# Altium schematic sheet IMU3.3X.SchDoc(IMU3.3X)
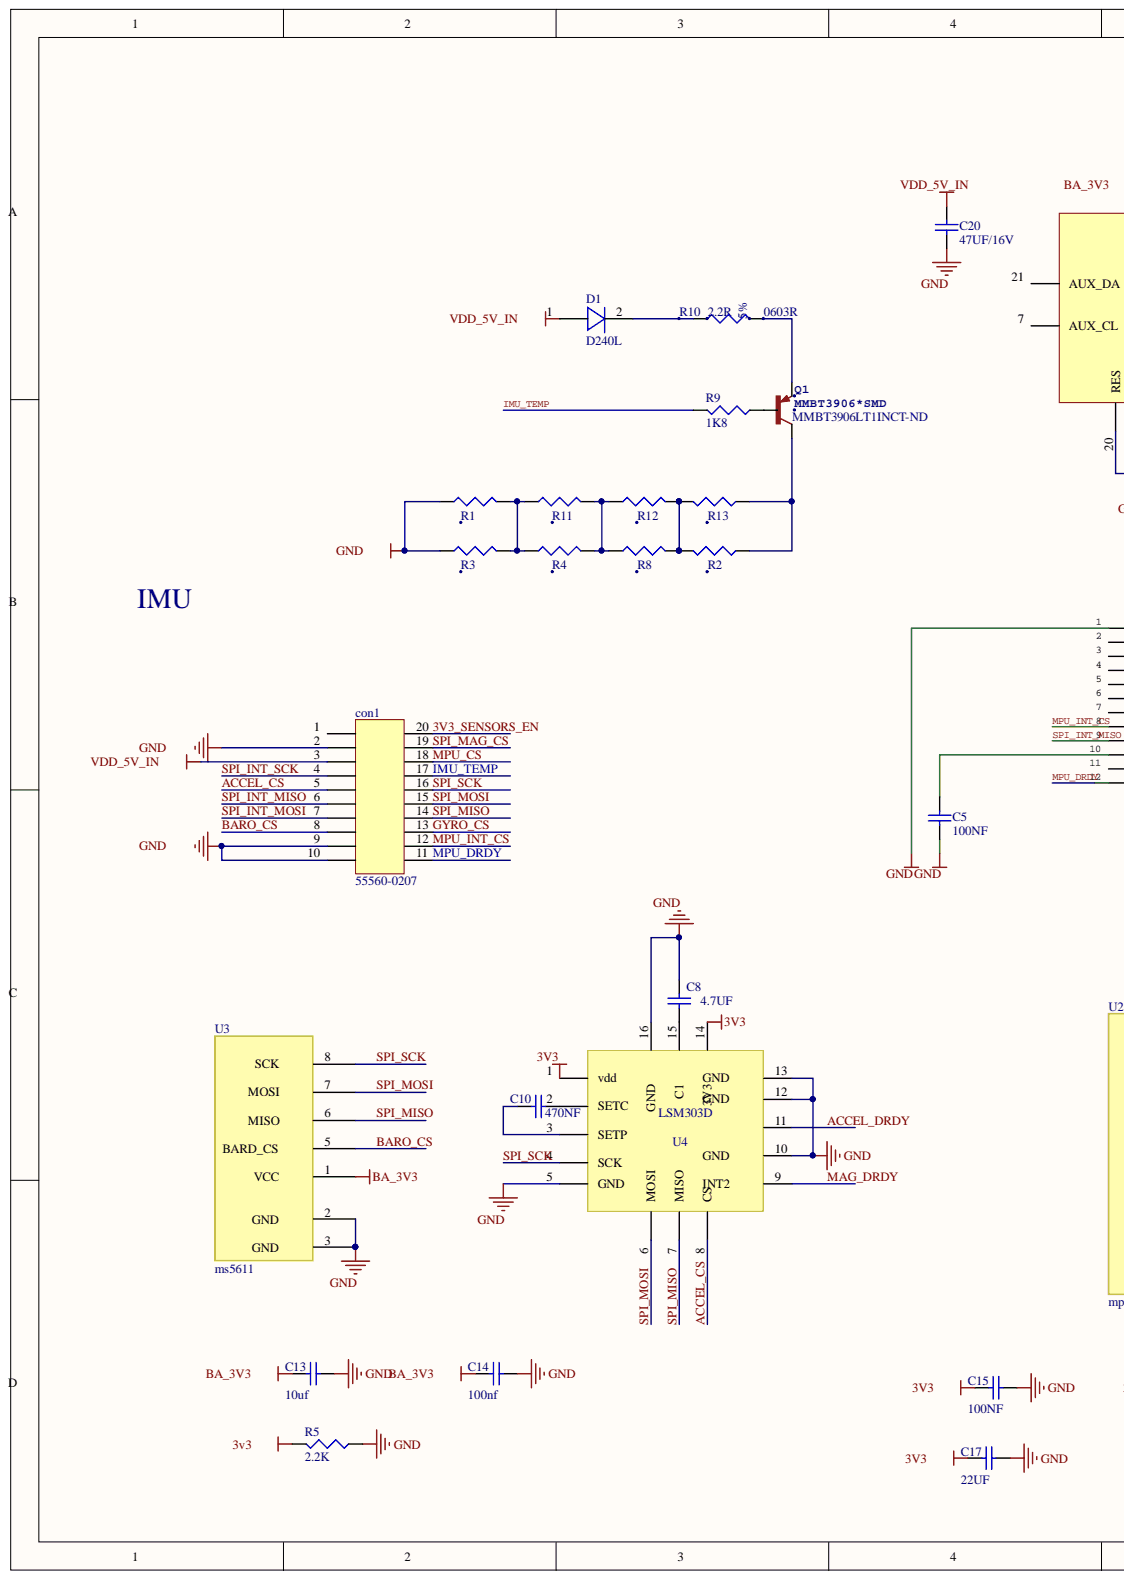
[diagram p0: IMU3.3X.SchDoc, left]
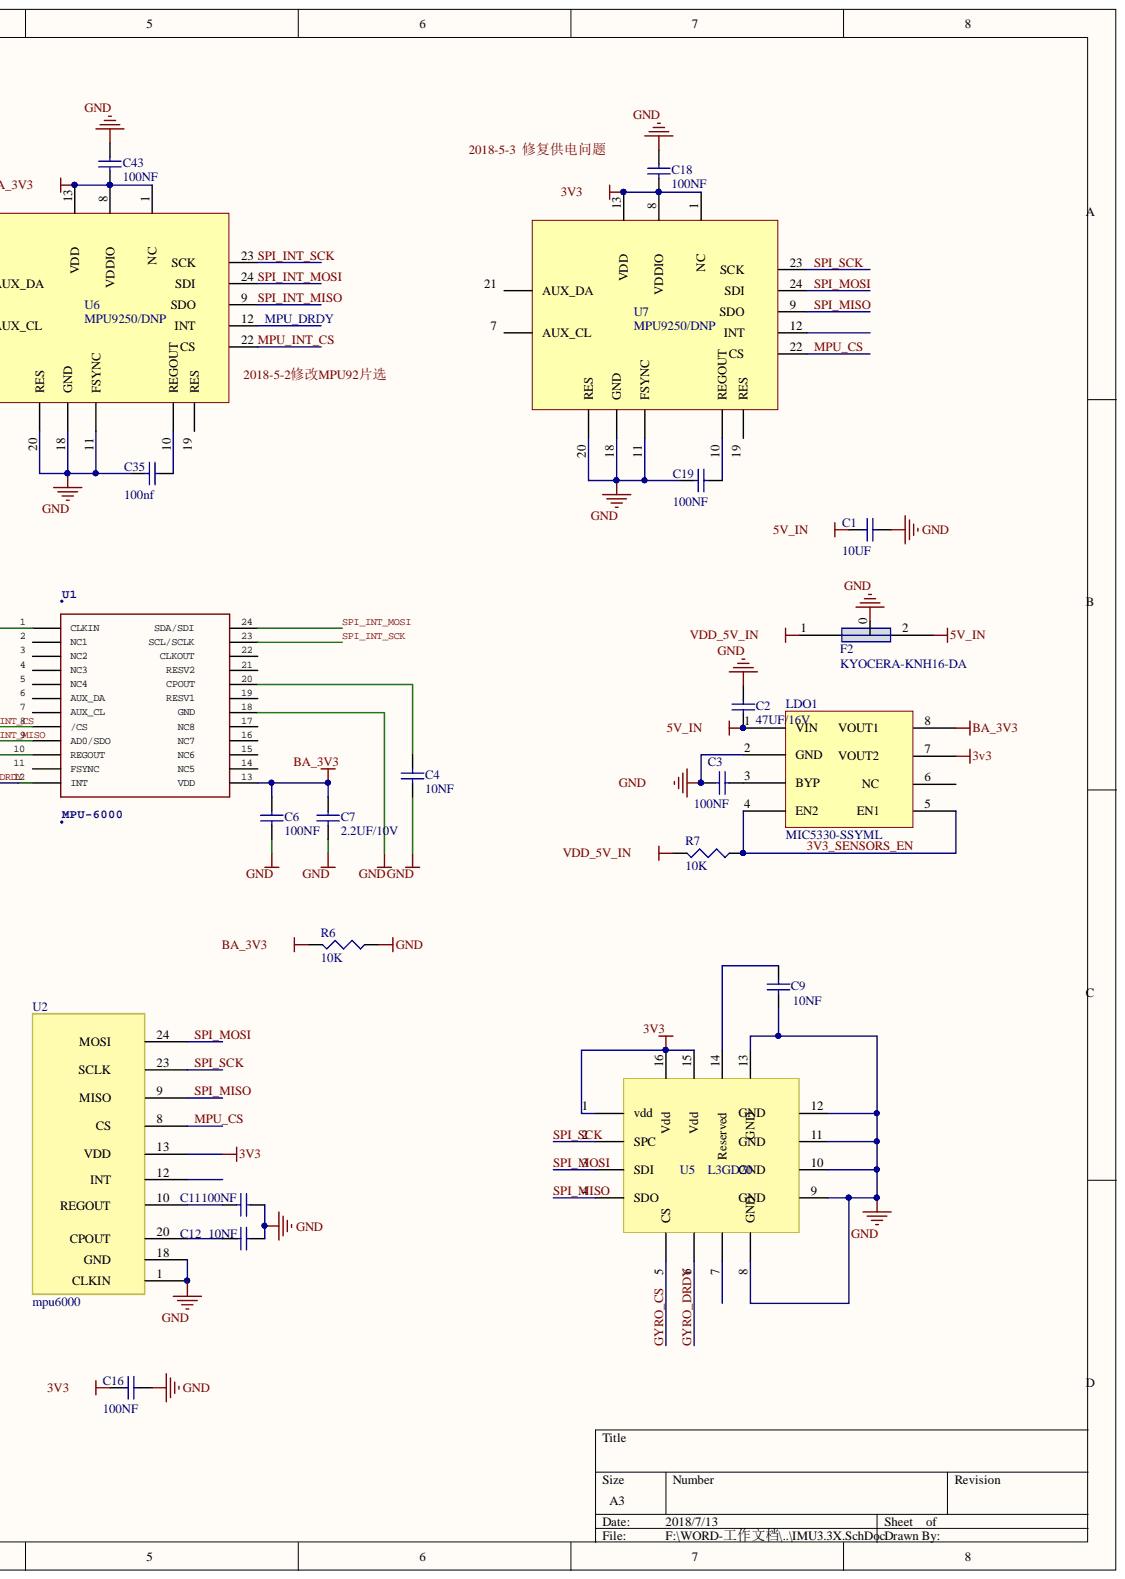
[diagram p1: IMU3.3X.SchDoc, right]

## Parts
C1  10UF  [0402CAP]
C2  47UF/16V  [0805CAP]
C3  100NF  [0402CAP]
C4  10NF  [0402CAP]
C5  100NF  [0402CAP]
C6  100NF  [0402CAP]
C7  2.2UF/10V  [0402CAP]
C8  4.7UF  [0805CAP]
C9  10NF  [0402CAP]
C10  470NF  [0402CAP]
C11  100NF  [0402CAP]
C12  10NF  [0402CAP]
C13  10uf  [0603c]
C14  100nf  [0402cap]
C15  100NF  [0402CAP]
C16  100NF  [0402CAP]
C17  22UF  [0805CAP]
C18  100NF  [0402CAP]
C19  100NF  [0402CAP]
C20  47UF/16V  [0805CAP]
C35  100nf  [0402CAP]
C43  100NF  [0402CAP]
con1  55560-0207  [55560-0207]
D1  D240L  [SOD-123]
F2  KYOCERA-KNH16-DA  [KYOCERA-KNH16-DA]
LDO1  MIC5330-SSYML  [MIC5530]
Q1  MMBT3906*SMD  Supplier Part Number 1: MMBT3906LT1INCT-ND  [SOT23-BEC]
R1  0R  [0603R]  (value not drawn)
R2  5R5/DNP  [0603R]  (value not drawn)
R3  5R5/DNP  [0603R]  (value not drawn)
R4  5R5/DNP  [0603R]  (value not drawn)
R5  2.2K  [0402r]
R6  10K  [0402r]
R7  10K  [0402r]
R8  5R5/DNP  [0603R]  (value not drawn)
R9  1K8  [0402r]
R10  2.2R  Tolerance: 5%  [0603R]
R11  0R  [0603R]  (value not drawn)
R12  51R  [0603R]  (value not drawn)
R13  51R  [0603R]  (value not drawn)
U1  MPU-6000  [MPU6000]
U2  mpu6000  [MPU6000]
U3  ms5611  [ms5611]
U4  LSM303D  [LSM303D]
U5  L3GD20  [L3GD20]
U6  MPU9250/DNP  [QNF24_0.4]
U7  MPU9250/DNP  [QNF24_0.4]

## Nets
3V3: C15.1 C16.1 C17.1 C18.1 LDO1.7 R5.2 U2.13 U4.1(vdd) U4.14 U5.1(vdd) U5.15 U5.16 U7.1 U7.8 U7.13
5V_IN: C1.1 C2.1 F2.2 LDO1.1(VIN)
BA_3V3: C6.2 C7.2 C13.1 C14.1 C43.1 LDO1.8 R6.2 U1.13 U3.1 U6.1 U6.8 U6.13
GND: C1.2 C2.2 C3.2 C4.1 C5.1 C6.1 C7.1 C8.2 C9.1 C11.2 C12.2 C13.2 C14.2 C15.2 C16.2 C17.2 C18.2 C19.1 C20.1 C35.1 C43.2 con1.2 con1.9 con1.10 F2.0 LDO1.2(GND) R1.1 R3.1 R5.1 R6.1 U1.1(CLKIN) U1.18 U2.1 U2.18 U3.2 U3.3 U4.5(GND) U4.10 U4.12 U4.13 U4.16 U5.8(GND) U5.9 U5.10 U5.11 U5.12 U5.13 U6.11(FSYNC) U6.18(GND) U6.20(RES) U7.11(FSYNC) U7.18(GND) U7.20(RES)
VDD_5V_IN: C20.2 con1.3 D1.1 F2.1 R7.2
3V3_SENSORS_EN: con1.20 LDO1.4(EN2) LDO1.5 R7.1
ACCEL_CS: con1.5 U4.8(CS)
ACCEL_DRDY: U4.11
BARO_CS: con1.8 U3.5
GYRO_CS: con1.13 U5.5(CS)
GYRO_DRDY: U5.6
IMU_TEMP: con1.17 R9.2
MAG_DRDY: U4.9
MPU_CS: con1.18 U2.8 U7.22
MPU_DRDY: con1.11 U1.12(INT) U6.12
MPU_INT_CS: con1.12 U1.8(/CS) U6.22
SPI_INT_MISO: con1.6 U1.9(AD0/SDO) U6.9
SPI_INT_MOSI: con1.7 U1.24 U6.24
SPI_INT_SCK: con1.4 U1.23 U6.23
SPI_MAG_CS: con1.19
SPI_MISO: con1.14 U2.9 U3.6 U4.7(MISO) U5.4(SDO) U7.9
SPI_MOSI: con1.15 U2.24 U3.7 U4.6(MOSI) U5.3(SDI) U7.24
SPI_SCK: con1.16 U2.23 U3.8 U4.4(SCK) U5.2(SPC) U7.23
NetC3_1: C3.1 LDO1.3(BYP)
NetC4_2: C4.2 U1.20
NetC5_2: C5.2 U1.10(REGOUT)
NetC8_1: C8.1 U4.15
NetC9_2: C9.2 U5.14
NetC10_1: C10.1 U4.3(SETP)
NetC10_2: C10.2 U4.2(SETC)
NetC11_1: C11.1 U2.10
NetC12_1: C12.1 U2.20
NetC19_2: C19.2 U7.10(REGOUT)
NetC35_2: C35.2 U6.10(REGOUT)
NetD1_2: D1.2 R10.1
NetQ1_B: Q1.B R9.1
NetQ1_C: Q1.C R2.1 R13.1
NetQ1_E: Q1.E R10.2
NetR1_2: R1.2 R3.2 R4.1 R11.1
NetR2_2: R2.2 R8.2 R12.2 R13.2
NetR4_2: R4.2 R8.1 R11.2 R12.1
2018-5-2修改MPU92片选:
2018-5-3  修复供电问题:

Not connected: con1 1; LDO1 6; U1 2(NC1) 3(NC2) 4(NC3) 5(NC4) 6(AUX_DA) 7(AUX_CL) 11(FSYNC) 14 15 16 17 19 21 22; U2 12; U5 7; U6 7(AUX_CL) 19(RES) 21(AUX_DA); U7 7(AUX_CL) 12 19(RES) 21(AUX_DA)

## Library data of the parts
C1 C2 C4-C20 C35 C43 = Cap: Capacitor; Value: 100pF; Component Kind: Standard; LatestRevisionDate: 17-Jul-2002; LatestRevisionNote: Re-released for DXP Platform.; Library Name: Miscellaneous Devices.IntLib; PackageDescription: Radial Cap, Thru-Hole; 2 Leads; 0.3 in Pin Spacing; PackageReference: RAD-0.3; Published: 8-Jun-2000; Signal Integrity: Cap; Simulation: CAP; Publisher: Altium Limited
C3 = Cap: Value: 100pF; Component Kind: Standard; LatestRevisionDate: 17-Jul-2002; LatestRevisionNote: Re-released for DXP Platform.; Library Name: Miscellaneous Devices.IntLib; PackageDescription: Radial Cap, Thru-Hole; 2 Leads; 0.3 in Pin Spacing; PackageReference: RAD-0.3; Published: 8-Jun-2000; Signal Integrity: Cap; Simulation: CAP; Publisher: Altium Limited
D1 = D204L: Component Kind: Standard; Library Name: CUAV.SchLib
LDO1 = MIC5530: Component Kind: Standard; Library Name: hack.SchLib
Q1 = MMBT3906*SMD: TRANSISTOR SWITCHING PNP SOT-23; PARTNO: MMBT 3906 LT1; Component Kind: Standard; Library Name: transistor-pnp.IntLib
R1 R3 R8 R10 R12 = Resistor: RES SMD 5.1 OHM 5% 1/4W 0603; Component Kind: Standard; Library Name: 3DR.SchLib
  R10: : 0603R; Value: 2.2R
R2 R4 R11 R13 = Resistor: Component Kind: Standard; Library Name: 3DR.SchLib
R5 = RMCF0402FT4K99: RES TF 4.99K OHM 1% 1/16W 0402; Manufacturer: Stackpole Electronics Inc; .Checked PCB: used; Power (Watts): 0.063W, 1/16W; Temperature Coefficient: ?00ppm/癈; Tolerance: ?%; Value: 4k99; .Checked SCH: used; Category: Resistors; Component Kind: Standard; ComponentLink1Description: http://www.seielect.com/Catalog/SEI-RMCF.pdf; ComponentLink1URL: http://www.seielect.com/Catalog/SEI-RMCF.pdf; Composition: Thick Film; Features: -; Height: 0.016" (0.40mm); Manufacturer Part Number: RMCF0402FT4K99; Number of Terminations: 2; Package / Case: 0402 (1005 Metric); Packaging: Cut Tape (CT); Price 1k [$]: 0.00384; Pricing 1: 1=0.04, 10=0.025, 50=0.0138, 100=0.0105, 250=0.0078, 500=0.00576, 1000=0.00384 (USD); Resistance (Ohms): 4.99K; RoHS: RoHS Compliant; Size / Dimension: 0.039" L x 0.020" W (1.00mm x 0.50mm); Stock 1: 48509; Supplier: Digikey; Supplier 1: Digi-Key; Supplier Part Number: RMCF0402FT4K99CT-ND; Supplier Part Number 1: RMCF0402FT4K99CT-ND; Library Name: Integrated_Library_RF_FEB_2012.IntLib
R6 R7 = RMCF0402FT4K99: RES TF 4.99K OHM 1% 1/16W 0402; Component Kind: Standard; Library Name: Integrated_Library_RF_FEB_2012.IntLib
R9 = RMCF0402FT4K99: Component Kind: Standard; Library Name: Integrated_Library_RF_FEB_2012.IntLib
U1 = MPU-6000: GYRO/ACCELEROMETER 6AXIS 24QFN; 3DR_PARTNO: ECM0834; Component Kind: Standard; DATASHEET: http://invensense.com/mems/gyro/documents/PS-MPU-6000A-00_v1.0.pdf; LINK: http://invensense.com/mems/gyro/mpu6000.html; MFGPN: MPU-6000; PARTNO: MPU-6000; Supplier 1: Digi-Key; Library Name: pixhawk2.SCHLIB
U6 U7 = MPU9250: Component Kind: Standard; Library Name: hack.SchLib
con1 = 55560-0207: Component Kind: Standard; Library Name: CUAV.SchLib
F2 = KYOCERA-KNH16-DA: Component Kind: Standard; Library Name: hack.SchLib
U2 = mpu6000: Component Kind: Standard; Library Name: hack.SchLib
U3 = ms5611: Component Kind: Standard; Library Name: hack.SchLib
U4 = LSM303D: Component Kind: Standard; Library Name: hack.SchLib
U5 = L3GD20: Component Kind: Standard; Library Name: hack.SchLib
